# Altium schematic sheet logique_hardware.SchDoc
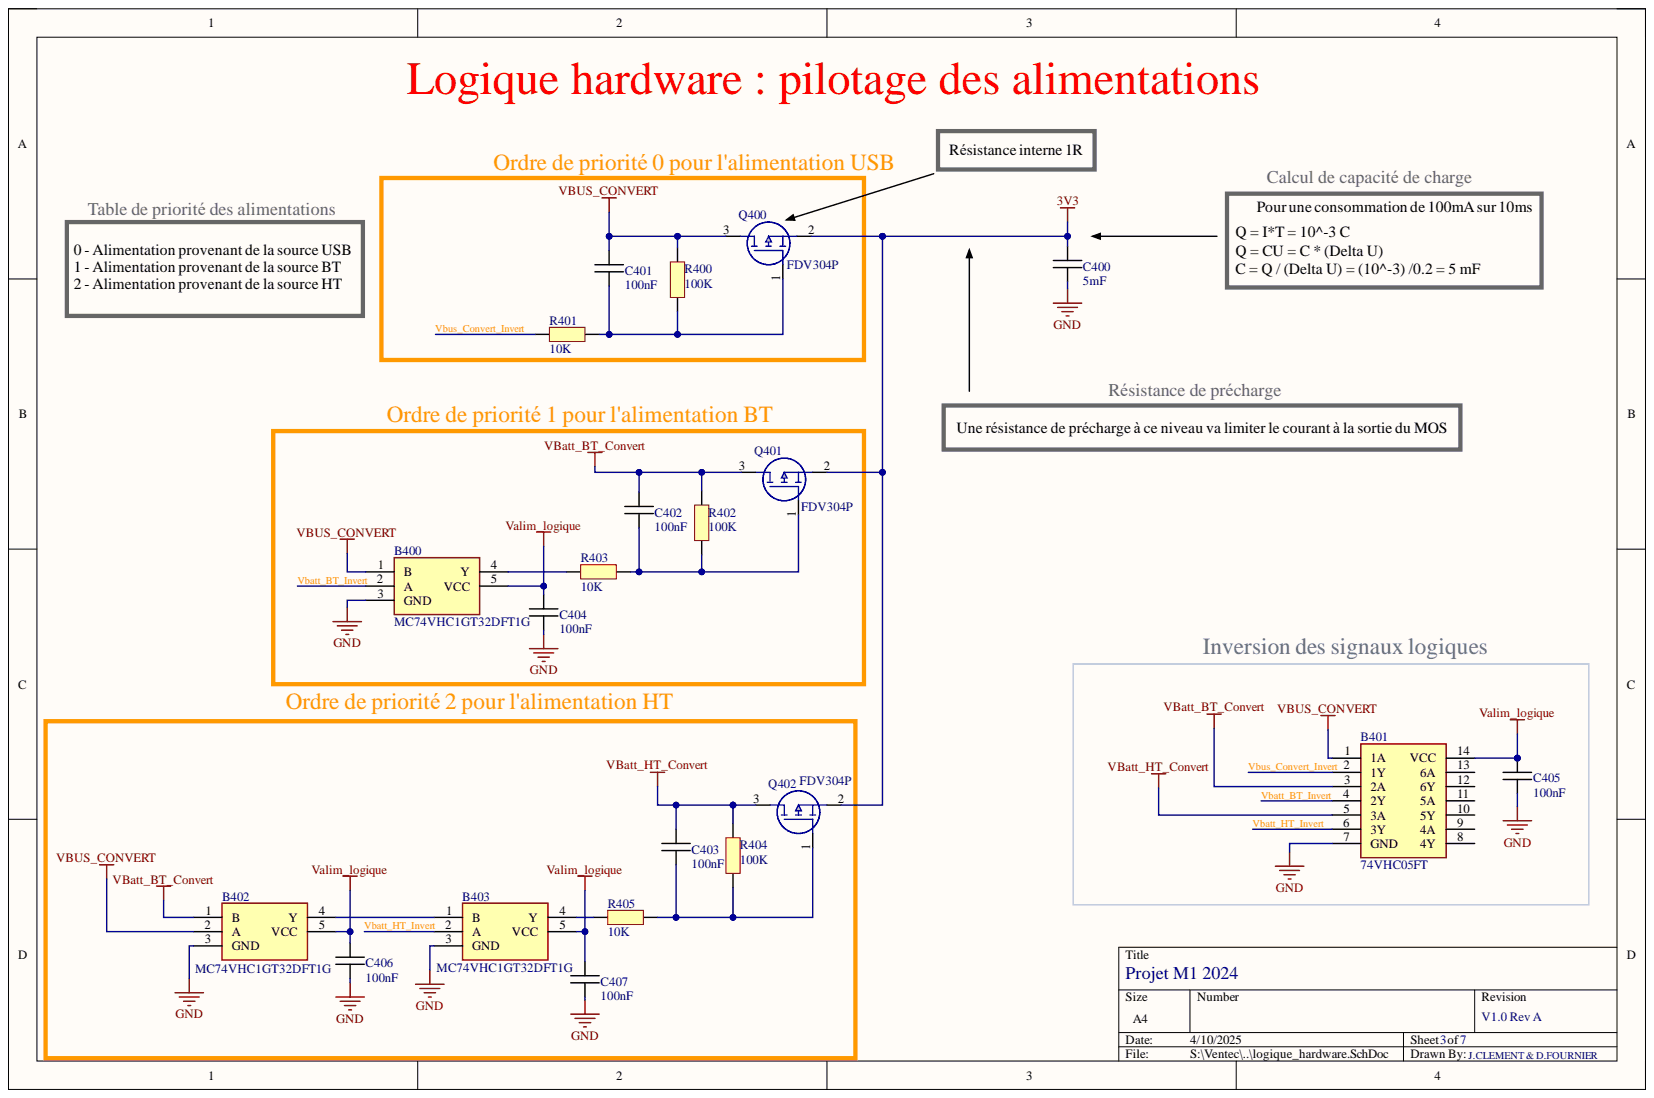
[diagram p0: logique_hardware.SchDoc]

## Parts
B400  MC74VHC1GT32DFT1G  [SOT65P210X110-5N]
B401  74VHC05FT  [SOP65P640X120-14N]
B402  MC74VHC1GT32DFT1G  [SOT65P210X110-5N]
B403  MC74VHC1GT32DFT1G  [SOT65P210X110-5N]
C400  5mF  [CAPC1005X06N]
C401  100nF  [CAPC1005X06N]
C402  100nF  [CAPC1005X06N]
C403  100nF  [CAPC1005X06N]
C404  100nF  [CAPC1005X06N]
C405  100nF  [CAPC1005X06N]
C406  100nF  [CAPC1005X06N]
C407  100nF  [CAPC1005X06N]
Q400  FDV304P  [SOT95P251X112-3N]
Q401  FDV304P  [SOT95P251X112-3N]
Q402  FDV304P  [SOT95P251X112-3N]
R400  100K  [RESC1005X06N]
R401  10K  [RESC1005X06N]
R402  100K  [RESC1005X06N]
R403  10K  [RESC1005X06N]
R404  100K  [RESC1005X06N]
R405  10K  [RESC1005X06N]

## Nets
3V3: C400.1 Q400.2 Q401.2 Q402.2
GND: B400.3(GND) B401.7(GND) B402.3(GND) B403.3(GND) C400.2 C404.2 C405.2 C406.2 C407.2
Valim_logique: B400.5(VCC) B401.14(VCC) B402.5(VCC) B403.5(VCC) C404.1 C405.1 C406.1 C407.1
VBatt_BT_Convert: B401.3(2A) B402.1(B) C402.1 Q401.3 R402.2
VBatt_HT_Convert: B401.5(3A) C403.1 Q402.3 R404.2
VBUS_CONVERT: B400.1(B) B401.1(1A) B402.2(A) C401.1 Q400.3 R400.2
Vbatt_BT_Invert: B400.2(A) B401.4(2Y)
Vbatt_HT_Invert: B401.6(3Y) B403.2(A)
Vbus_Convert_Invert: B401.2(1Y) R401.1
NetB400_4: B400.4(Y) R403.1
NetB402_4: B402.4(Y) B403.1(B)
NetB403_4: B403.4(Y) R405.1
NetC401_2: C401.2 Q400.1 R400.1 R401.2
NetC402_2: C402.2 Q401.1 R402.1 R403.2
NetC403_2: C403.2 Q402.1 R404.1 R405.2

Not connected: B401 8(4Y) 9(4A) 10(5Y) 11(5A) 12(6Y) 13(6A)

## Library data of the parts
B400 B402 B403 = MC74VHC1GT32DFT1G: Single 2-Input OR Gate, TTL Level; Manufacturer_Name: onsemi; Component Kind: Standard; Library Name: SCHLibrary.SchLib; Manufacturer_Part_Number: MC74VHC1GT32DFT1G; Mouser Part Number: 863-C74VHC1GT32DFT1G
B401 = 74VHC05FT: Logic Gates CMOS LOGIC IC INVERTER; Manufacturer_Name: Toshiba; Component Kind: Standard; Library Name: SCHLibrary.SchLib; Manufacturer_Part_Number: 74VHC05FT; Mouser Part Number: 757-74VHC05FT
C400-C407 = Capacitor: Component Kind: Standard; Library Name: SCHLibrary.SchLib
Q400-Q402 = FDV304P: ON SEMICONDUCTOR - FDV304P - Power MOSFET, P Channel, 25 V, 460 mA, 1.22 ohm, SOT-23, Surface Mount; Manufacturer_Name: onsemi; Geometry.Height: 1.12mm; Arrow Part Number: FDV304P; Arrow Price/Stock: https://www.arrow.com/en/products/fdv304p/on-semiconductor?utm_currency=USD&region=europe; Component Kind: Standard; Datasheet Link: https://componentsearchengine.com/Datasheets/2/FDV304P.pdf; Library Name: SCHLibrary.SchLib; Manufacturer_Part_Number: FDV304P; Mouser Part Number: 512-FDV304P; Mouser Price/Stock: https://www.mouser.co.uk/ProductDetail/onsemi-Fairchild/FDV304P?qs=FOlmdCx%252BAA1BAlCQZu888w%3D%3D
R400-R405 = Resistor: Component Kind: Standard; Library Name: SCHLibrary.SchLib
